# Altium schematic sheet LED_clock.SchDoc(LED_clock)
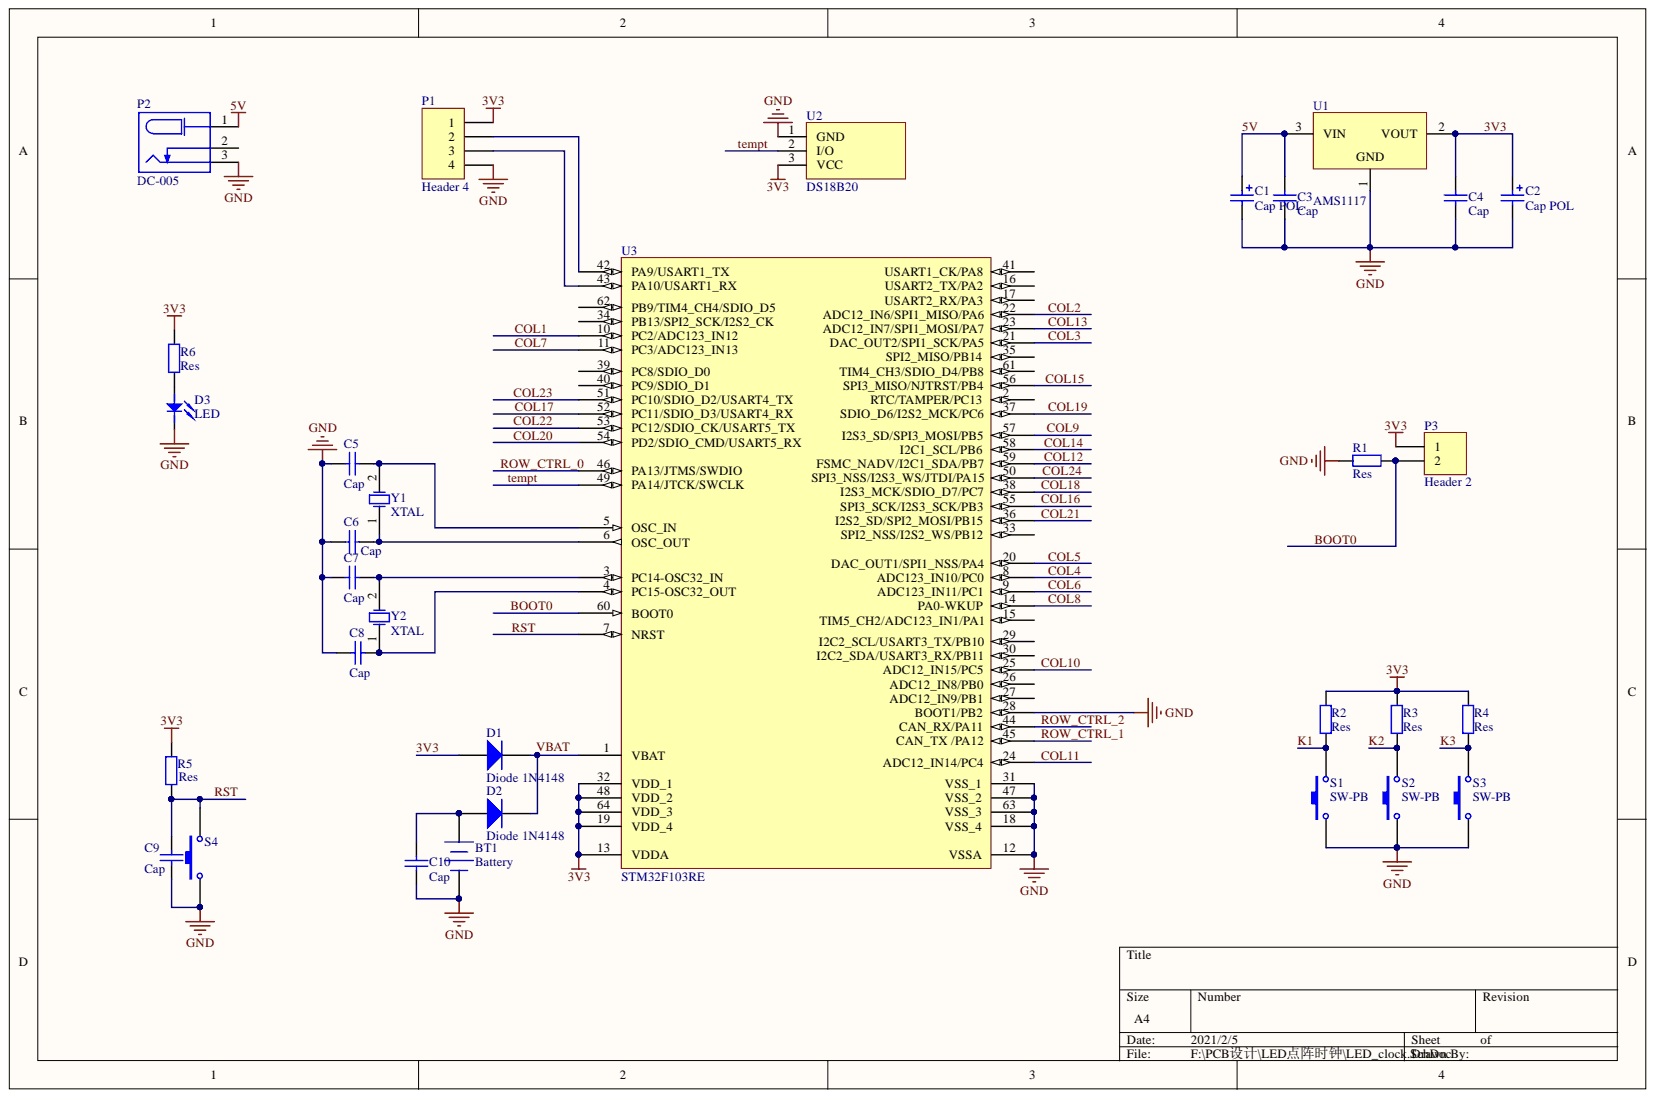
[diagram p0: LED_clock.SchDoc]

## Parts
BT1  Battery  [CR2032_B]
C1  Cap POL  [LC-CASE-B_3528]
C2  Cap POL  [LC-CASE-B_3528]
C3  Cap  [C-0603]
C4  Cap  [C-0603]
C5  Cap  [C-0603]
C6  Cap  [C-0603]
C7  Cap  [C-0603]
C8  Cap  [C-0603]
C9  Cap  [C-0603]
C10  Cap  [C-0603]
D1  Diode 1N4148  [SOD-123/1206]
D2  Diode 1N4148  [SOD-123/1206]
D3  LED  [LED-0603-Red]
P1  Header 4  [HDR2.54-WI-4P]
P2  DC-005  [DC-005]
P3  Header 2  [HDR2.54-WI-2P]
R1  Res  [R-0603-M]
R2  Res  [R-0603-M]
R3  Res  [R-0603-M]
R4  Res  [R-0603-M]
R5  Res  [R-0603-M]
R6  Res  [R-0603-M]
S1  SW-PB  [SB-6*6*5 90°]
S2  SW-PB  [SB-6*6*5 90°]
S3  SW-PB  [SB-6*6*5 90°]
S4  SW-PB  [SB-6*6*5 90°]  (value not drawn)
U1  AMS1117  [SOT-223]
U2  DS18B20  [HDR2.54-M-WS-3P]
U3  STM32F103RE  [LQFP64]
Y1  XTAL  [49S]
Y2  XTAL  [49S]

## Nets
GND: BT1.2 C1.2 C2.2 C3.1 C4.1 C5.1 C6.1 C7.1 C8.1 C9.1 C10.1 D3.2 P1.4 P2.3 R1.1 S1.1 S2.1 S3.1 S4.1 U1.1 U2.1 U3.12(VSSA) U3.18(VSS_4) U3.28(BOOT1/PB2) U3.31(VSS_1) U3.47(VSS_2) U3.63(VSS_3)
3V3: C2.1 C4.2 D1.1 P1.1 P3.1 R2.1 R3.1 R4.1 R5.2 R6.2 U1.2 U2.3 U3.13(VDDA) U3.19(VDD_4) U3.32(VDD_1) U3.48(VDD_2) U3.64(VDD_3)
5V: C1.1 C3.2 P2.1 U1.3
BOOT0: P3.2 R1.2 U3.60(BOOT0)
COL1: U3.10(PC2/ADC123_IN12)
COL2: U3.22(ADC12_IN6/SPI1_MISO/PA6)
COL3: U3.21(DAC_OUT2/SPI1_SCK/PA5)
COL4: U3.8(ADC123_IN10/PC0)
COL5: U3.20(DAC_OUT1/SPI1_NSS/PA4)
COL6: U3.9(ADC123_IN11/PC1)
COL7: U3.11(PC3/ADC123_IN13)
COL8: U3.14(PA0-WKUP)
COL9: U3.57(I2S3_SD/SPI3_MOSI/PB5)
COL10: U3.25(ADC12_IN15/PC5)
COL11: U3.24(ADC12_IN14/PC4)
COL12: U3.59(FSMC_NADV/I2C1_SDA/PB7)
COL13: U3.23(ADC12_IN7/SPI1_MOSI/PA7)
COL14: U3.58(I2C1_SCL/PB6)
COL15: U3.56(SPI3_MISO/NJTRST/PB4)
COL16: U3.55(SPI3_SCK/I2S3_SCK/PB3)
COL17: U3.52(PC11/SDIO_D3/USART4_RX)
COL18: U3.38(I2S3_MCK/SDIO_D7/PC7)
COL19: U3.37(SDIO_D6/I2S2_MCK/PC6)
COL20: U3.54(PD2/SDIO_CMD/USART5_RX)
COL21: U3.36(I2S2_SD/SPI2_MOSI/PB15)
COL22: U3.53(PC12/SDIO_CK/USART5_TX)
COL23: U3.51(PC10/SDIO_D2/USART4_TX)
COL24: U3.50(SPI3_NSS/I2S3_WS/JTDI/PA15)
K1: R2.2 S1.2
K2: R3.2 S2.2
K3: R4.2 S3.2
ROW_CTRL_0: U3.46(PA13/JTMS/SWDIO)
ROW_CTRL_1: U3.45(CAN_TX_/PA12)
ROW_CTRL_2: U3.44(CAN_RX/PA11)
RST: C9.2 R5.1 S4.2 U3.7(NRST)
tempt: U2.2 U3.49(PA14/JTCK/SWCLK)
NetBT1_1: BT1.1 C10.2 D2.1
NetC5_2: C5.2 U3.5(OSC_IN) Y1.2
NetC6_2: C6.2 U3.6(OSC_OUT) Y1.1
NetC7_2: C7.2 U3.3(PC14-OSC32_IN) Y2.2
NetC8_2: C8.2 U3.4(PC15-OSC32_OUT) Y2.1
NetD1_2: D1.2 D2.2 U3.1(VBAT)
NetD3_1: D3.1 R6.1
NetP1_2: P1.2 U3.42(PA9/USART1_TX)
NetP1_3: P1.3 U3.43(PA10/USART1_RX)
NetP2_2: P2.2
VBAT:

Not connected: U3 2(RTC/TAMPER/PC13) 15(TIM5_CH2/ADC123_IN1/PA1) 16(USART2_TX/PA2) 17(USART2_RX/PA3) 26(ADC12_IN8/PB0) 27(ADC12_IN9/PB1) 29(I2C2_SCL/USART3_TX/PB10) 30(I2C2_SDA/USART3_RX/PB11) 33(SPI2_NSS/I2S2_WS/PB12) 34(PB13/SPI2_SCK/I2S2_CK) 35(SPI2_MISO/PB14) 39(PC8/SDIO_D0) 40(PC9/SDIO_D1) 41(USART1_CK/PA8) 61(TIM4_CH3/SDIO_D4/PB8) 62(PB9/TIM4_CH4/SDIO_D5)

## Library data of the parts
BT1 = Battery: Multicell Battery; Published: 24-Mar-1999; PackageReference: BAT-2; PackageDescription: Battery; 2 Leads; Library Name: Miscellaneous Devices.SchLib; LatestRevisionNote: Re-released for DXP Platform.; LatestRevisionDate: 17-Jul-2002; Component Kind: Standard; Publisher: Altium Limited
D1 D2 = Diode 1N4148: High Conductance Fast Diode; Simulation: 1N4148; Signal Integrity: 1N4148; Published: 8-Jun-2000; PackageReference: DO-35; PackageDescription: Diode, Axial; Body 3.8 x 1.9 mm (LxDia. max), Lead Dia. 0.53 mm (max); Library Name: Miscellaneous Devices.SchLib; LatestRevisionNote: Re-released for DXP Platform.; LatestRevisionDate: 17-Jul-2002; DatasheetVersion: 1997; ComponentLink2URL: http://www.fairchildsemi.com/ds/1N%2F1N4148.pdf; ComponentLink2Description: Datasheet; ComponentLink1URL: http://www.fairchildsemi.com/; ComponentLink1Description: Manufacturer Link; Component Kind: Standard; Code_JEDEC: DO-204-AH; Publisher: Altium Limited
P1 = Header 4: Header, 4-Pin; Signal Integrity: Connector; Library Name: Miscellaneous Connectors.IntLib; LatestRevisionNote: Re-released for DXP Platform.; LatestRevisionDate: 17-Jul-2002; Component Kind: Standard; Publisher: Altium Limited
P3 = Header 2: Header, 2-Pin; Signal Integrity: Connector; Library Name: Miscellaneous Connectors.IntLib; LatestRevisionNote: Re-released for DXP Platform.; LatestRevisionDate: 17-Jul-2002; Component Kind: Standard; Publisher: Altium Limited
S1-S4 = SW-PB: Switch; Published: 24-Mar-1999; PackageReference: SPST-2; PackageDescription: Switch; 2 Leads; LatestRevisionNote: Re-released for DXP Platform.; LatestRevisionDate: 17-Jul-2002; Component Kind: Standard; Publisher: Altium Limited
  S1-S3: Library Name: General Components.SchLib
  S4: Library Name: Miscellaneous Devices.SchLib
U3 = STM32F103RE: 32位STM单片机; 版权归属: 源创客; 学习资源: www.ooocke.com; Library Name: 2.1   - STM32系列单片机.SchLib; Component Kind: Standard; BOM名称: 32位STM单片机
Y1 Y2 = XTAL: Crystal Oscillator; Published: 8-Jun-2000; PackageReference: R38; PackageDescription: Crystal, Thru-Hole; 2 Leads; Body 3.1 x 8.2 mm (Dia.xH); Library Name: General Components.SchLib; LatestRevisionNote: Re-released for DXP Platform.; LatestRevisionDate: 17-Jul-2002; Component Kind: Standard; Publisher: Altium Limited
C1 C2 = Cap POL: Library Name: General Components.SchLib; Component Kind: Standard
C3-C10 = Cap: Library Name: General Components.SchLib; Component Kind: Standard
D3 = LED: Library Name: General Components.SchLib; Component Kind: Standard
P2 = DC-005: Library Name: General Components.SchLib; Component Kind: Standard
R1-R6 = Res: Library Name: General Components.SchLib; Component Kind: Standard
U1 = AMS1117: Library Name: Analog Devices.SchLib; Component Kind: Standard
U2 = DS18B20: Library Name: General Components.SchLib; Component Kind: Standard
